# Altium schematic sheet BAT.SchDoc
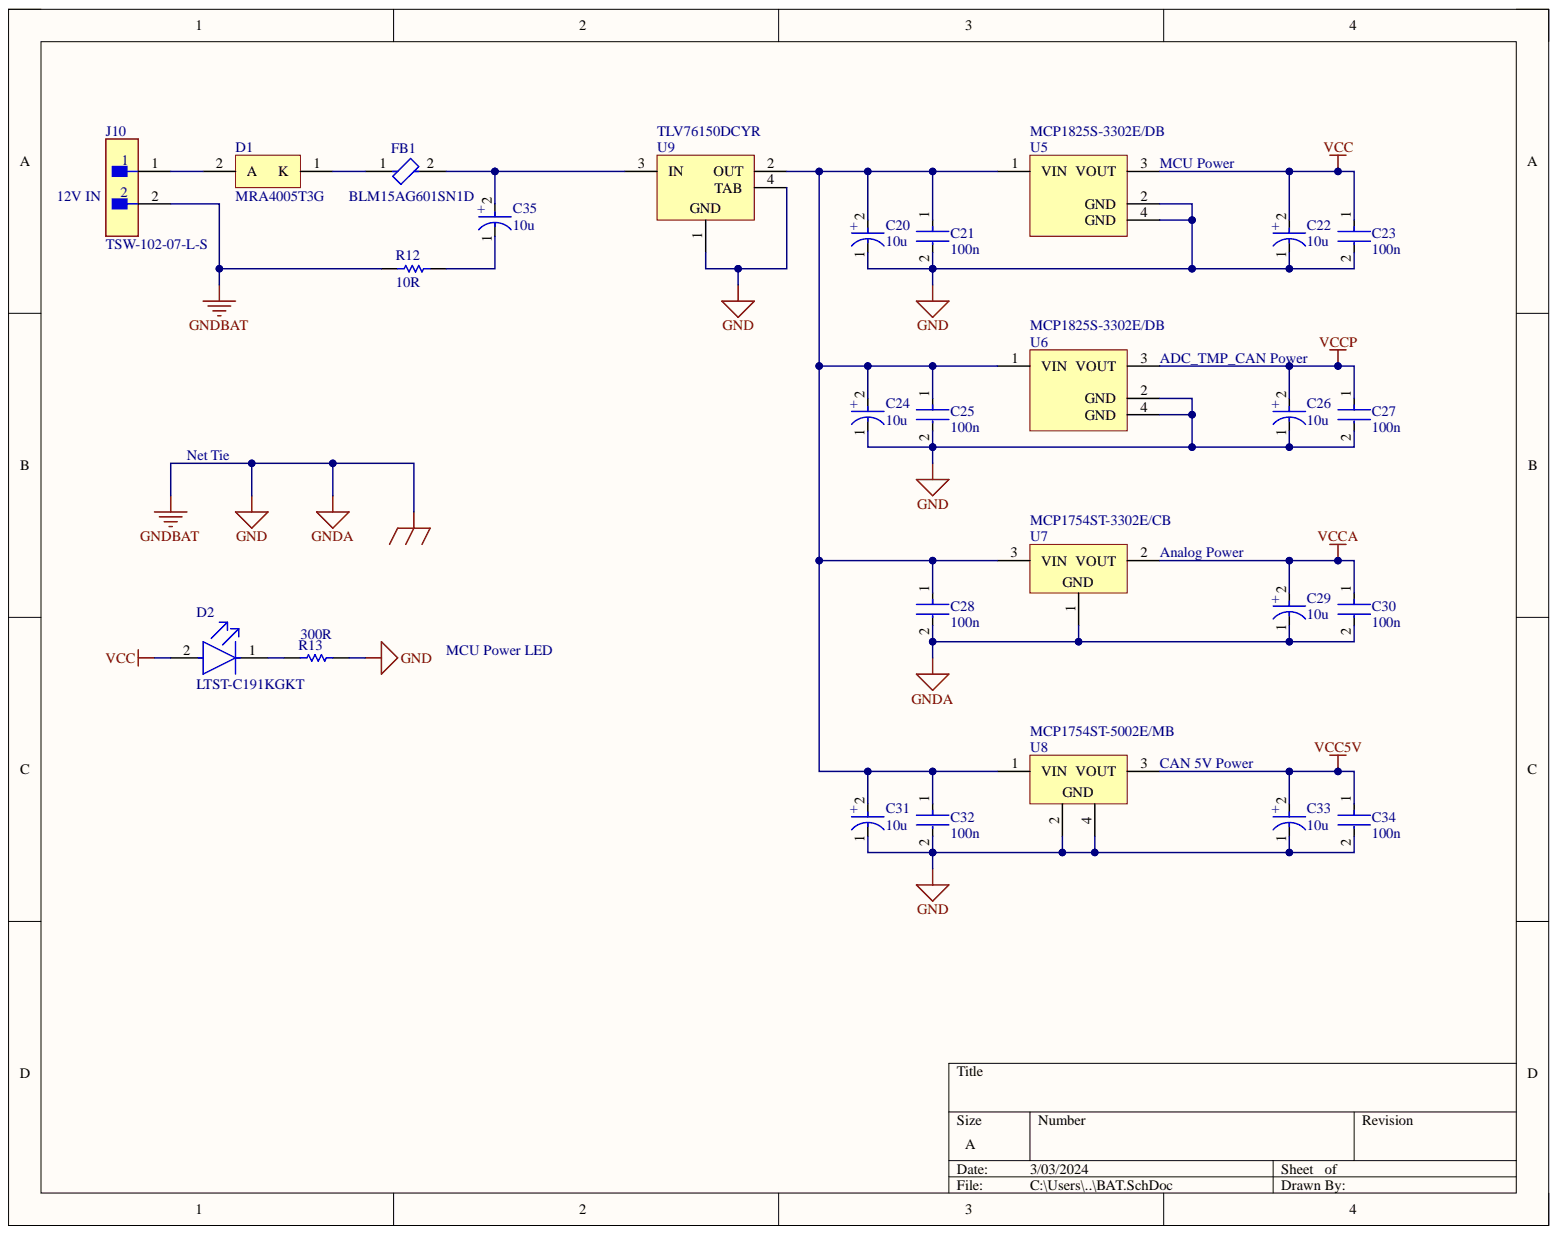
[diagram p0: BAT.SchDoc]

## Parts
C20  10u  [FP-TAJA-MFG]
C21  100n  [FP-GRM033-0_03-MFG]
C22  10u  [FP-TAJA-MFG]
C23  100n  [FP-GRM033-0_03-MFG]
C24  10u  [FP-TAJA-MFG]
C25  100n  [FP-GRM033-0_03-MFG]
C26  10u  [FP-TAJA-MFG]
C27  100n  [FP-GRM033-0_03-MFG]
C28  100n  [FP-GRM033-0_03-MFG]
C29  10u  [FP-TAJA-MFG]
C30  100n  [FP-GRM033-0_03-MFG]
C31  10u  [FP-TAJA-MFG]
C32  100n  [FP-GRM033-0_03-MFG]
C33  10u  [FP-TAJA-MFG]
C34  100n  [FP-GRM033-0_03-MFG]
C35  10u  [FP-TAJA-MFG]
D1  MRA4005T3G  [ONSC-SMA-2-403D-02_V]
D2  LTST-C191KGKT  [FP-LTST-C191KSKT-MFG]
FB1  BLM15AG601SN1D  [FP-BLM15-0_05-MFG]
J10  TSW-102-07-L-S  [FP-TSW-102-07-L-S-MFG]
R12  10R  [RESC2013X60X35ML10T20]
R13  300R  [RESC1608X55X30LL20T20]
U5  MCP1825S-3302E/DB  [SOT-223-DB3_N]
U6  MCP1825S-3302E/DB  [SOT-223-DB3_N]
U7  MCP1754ST-3302E/CB  [MCHP-SOT-23A-CB3_N]
U8  MCP1754ST-5002E/MB  [MCHP-SOT-89-MB3_L]
U9  TLV76150DCYR  [DCY0004A_L]

## Nets
GND: C20.1 C21.2 C22.1 C23.2 C24.1 C25.2 C26.1 C27.2 C28.2 C29.1 C30.2 C31.1 C32.2 C33.1 C34.2 J10.2 R12.1 R13.2 U5.2(GND) U5.4(GND) U6.2(GND) U6.4(GND) U7.1 U8.2 U8.4 U9.1 U9.4(TAB)
VCC: C22.2 C23.1 D2.2 U5.3(VOUT)
VCC5V: C33.2 C34.1 U8.3(VOUT)
VCCA: C29.2 C30.1 U7.2
VCCP: C26.2 C27.1 U6.3(VOUT)
NetC20_2: C20.2 C21.1 C24.2 C25.1 C28.1 C31.2 C32.1 U5.1(VIN) U6.1(VIN) U7.3 U8.1(VIN) U9.2(OUT)
NetC35_1: C35.1 R12.2
NetC35_2: C35.2 FB1.2 U9.3(IN)
NetD1_1: D1.1 FB1.1
NetD1_2: D1.2 J10.1
NetD2_1: D2.1 R13.1

## Library data of the parts
C20 C22 C24 C26 C29 C31 C33 C35 = CMP-04424-000097-1: 10uF 6.3V  ±10% 4Ω 1206  SMD Tantalum Capacitor; Dissipation Factor: 6%; ESR (Equivalent Series Resistance): 4R; Leakage Current: 600nA; Polarity: Polar; Q Factor: 6; Tolerance: 20%; Capacitance: 10uF; Case Code (Imperial): 1206; Case Code (Metric): 3216; Case/Package: 1206; Component Kind: Standard; Construction: Flat; Depth: 1.6mm; Dielectric Material: Tantalum; Features: General Purpose; Height: 1.6mm; Height - Seated (Max): 0.071inch; Lead Free: Lead Free; Length: 3.2mm; Library Name: Altium Content Vault; Max Operating Temperature: 125°C; Min Operating Temperature: -55°C; Mount: Surface Mount; Package Quantity: 1; Packaging: Tape and Reel; Radiation Hardening: No; REACH SVHC: No SVHC; RoHS Compliant: Yes; Series: TAJ; Termination: SMD/SMT; Voltage: 6.3V; Voltage Rating: 6.3V; Voltage Rating (DC): 6.3V; Width: 1.6mm
C21 C23 C25 C27 C28 C30 C32 C34 = CMP-06035-000062-2: Chip Multilayer Ceramic Capacitors for General Purpose, 0201, 0.10uF, X5R, 15%, 10%, 6.3V; Capacitance: 100nF; Case Code (Imperial): 0201; Case Code (Metric): 0603; Case/Package: 0201; Component Kind: Standard; Depth: 0.3mm; Dielectric: X5R; Height: 0.3mm; Lead Free: Lead Free; Length: 0.6mm; Library Name: Altium Content Vault; Material: Ceramic; Max Operating Temperature: 85°C; Min Operating Temperature: -55°C; Mount: Surface Mount; Package Quantity: 1; Packaging: Tape and Reel; Radiation Hardening: No; REACH SVHC: No SVHC; Termination: SMD/SMT; Thickness: 0.013inch; Tolerance: 10%; Voltage: 6.3V; Voltage Rating: 6.3V; Voltage Rating (DC): 6.3V; Weight: 6E-06oz; Width: 0.012inch
D1 = CMP-0894-00001-3: Surface Mount Standard Recovery Power Rectifier, 2-Pin SMA, Pb-Free, Tape and Reel; Manufacturer: On Semiconductor; IFSM Max (A): 30; IO(rec) Max (A): 1; VRRM Max (V): 600; Component Kind: Standard; ComponentLink1Description: Manufacturer URL; ComponentLink1URL: http://www.onsemi.com/; ComponentLink2Description: Datasheet; ComponentLink2URL: http://www.onsemi.com/pub_link/Collateral/MRA4003T3-D.PDF; ComponentLink3Description: Package Specification; ComponentLink3URL: http://www.onsemi.com/pub_link/Collateral/403D-02.PDF; DatasheetVersion: Rev. 9; Library Name: Altium Content Vault; Mounting Technology: Surface Mount; PackageDescription: 2-Pin Small Molded Package, J-Bend Lead, Body 2.605 x 4.315 mm; PackageReference: SMA-2-403D-02; PackageVersion: Rev. G, 07/2012; Packing: Tape and Reel; PartNumber: MRA4005T3G; RoHS: TRUE; Type: Standard Recovery
D2 = CMP-22017-000025-1: LED YELLOW CLEAR SMD; Dominant Wavelength: 597nm; Forward Current: 30mA; Forward Voltage: 2.4V; Lens Color: Colorless; Lens Style: Diffused; Luminous Intensity: 180mcd; Number of Elements: 1; Power Dissipation: 75mW; Reverse Voltage: 5V; Test Current: 20mA; Viewing Angle: 130°; Wavelength: 588nm; Case Code (Imperial): 0603; Case Code (Metric): 1608; Case/Package: 0603; Color: Yellow; Component Kind: Standard; Depth: 0.8mm; Height: 0.55mm; Illumination Color: Yellow; Lead Free: Lead Free; Length: 1.6mm; Lens Transparency: Clear; Library Name: Altium Content Vault; Max Operating Temperature: 85°C; Min Operating Temperature: -55°C; Mount: Surface Mount; Number of LEDs: 1; Number of Pins: 2; Package Quantity: 1; Packaging: Tape and Reel; Power Rating: 75mW; Radiation Hardening: No; Width: 0.8mm
FB1 = CMP-0686-00187-4: Chip Ferrite Bead, 0402, 600Ω @ 100MHz, 0.52Ω, 25%, 300mA; DC Resistance (DCR): 520mR; DC Resistance-Max: 600mR; Impedance: 600R; Material: Steel; Number of Circuits: 1; Shielding: Unshielded; Case Code (Imperial): 0402; Case Code (Metric): 1005; Case/Package: 0402; Component Kind: Standard; Core Material: Ferrite; Current Rating: 300mA; DC Current: 300mA; Depth: 0.55mm; Frequency: 100MHz; Height: 0.5mm; Lead Free: Lead Free; Length: 1mm; Library Name: Altium Content Vault; Max Current Rating: 300mA; Max DC Current: 300mA; Max Operating Temperature: 125°C; Military Standard: Not; Min Operating Temperature: -55°C; Number of Lines: 1; Number of Pins: 2; Package Quantity: 10000; Packaging: Tape and Reel; Radiation Hardening: No; REACH SVHC: No SVHC; RoHS Compliant: Yes; Series: BLM15AG_SN; Termination: SMD/SMT; Test Frequency: 100MHz; Tolerance: 25%; Width: 0.5mm
J10 = CMP-02766-001428-1: CONN HEADER VERT 2POS 2.54MM; Connector Type: Male Pin; Current Rating: 7.6A; Gender: Male; Max Voltage Rating (AC): 550V; Number of Contacts: 2; Color: Black; Component Kind: Standard; Contact Material: Bronze; Contact Mating Length: 0.23inch; Contact Plating: Gold; Contact Type: Male Pin; Depth: 2.54mm; Housing Color: Black; Housing Material: Polyester; Lead Free: Lead Free; Length: 5.08mm; Library Name: Altium Content Vault; Max Current Rating: 7.6A; Max Operating Temperature: 125°C; Min Operating Temperature: -55°C; Mount: Through Hole; Number of Positions: 2; Number of Rows: 1; Orientation: Straight; Package Quantity: 1; Packaging: Bulk; Pitch: 2.54mm; Radiation Hardening: No; REACH SVHC: No SVHC; RoHS Compliant: Yes; Series: TSW; Termination: Solder; Voltage Rating: 550V; Width: 2.54mm
R12 = CMP-2100-03670-1: Chip Resistor, 10 Ohm, +/- 1%, 0.125 W, -55 to 155 degC, 0805 (2012 Metric), RoHS, Tape and Reel; Manufacturer: Yageo; Max Power Dissipation: 125mW; Resistance: 10Ohm; Temperature Coefficient: 200ppm/degreesC; Voltage Rating: 150V; Case Code (Imperial): 0805; Case Code (Metric): 2012; Case/Package: 0805; Component Kind: Standard; Composition: ThickFilm; Datasheet URL: http://www.yageo.com/NewPortal/yageodocoutput?fileName=/pdf/R-Chip/PYu-RC_Group_51_RoHS_L_5.pdf; Datasheet Version: Rev. 5, 10/2016; Depth: 1.25mm; Height: 0.5mm; Lead Free: LeadFree; Length: 2mm; Library Name: Altium Content Vault; Manufacturer URL: http://www.yageo.com/; Max Operating Temperature: 155degC; Min Operating Temperature: -55degC; Number of Pins: 2; Number of Terminations: 2; Package Description: 2-Pin Surface Mounted Device, Body 2 x 1.25 mm; Package Quantity: 1; Package Reference: 0805; Packaging: TapeandReel; Power Rating: 125mW; REACH SVHC: NoSVHC; RoHSCompliant: true; Termination: SMD/SMT; Tolerance: 1%; Voltage Rating (DC): 150V; Width: 1.25mm
R13 = CMP-2000-00025-1: Manufacturer: Panasonic; Part Number: ERA3AEB301V; Component Kind: Standard; Library Name: Altium Content Vault
U5 U6 = CMP-0136-00458-1: 500 mA, Low Voltage, Low Quiescent Current LDO Regulator, 3-Pin SOT-223, Extended Temperature; Component Kind: Standard; DatasheetVersion: revB, Feb-2008; Library Name: Altium Content Vault; PackageDescription: 3-Lead Plastic Small Outline Transistor (DB) [SOT-223]; PackageReference: SOT-223-DB3; PackageVersion: revBB, Aug-2009
U7 = CMP-0136-01141-1: 150 mA, 16 V, High Performance LDO, -40 to 125 degC, 3-pin SOT-23A (CB3), Tape and Reel; Manufacturer: Microchip; Component Kind: Standard; ComponentLink1Description: Manufacturer URL; ComponentLink1URL: http://www.microchip.com; ComponentLink2Description: Datasheet; ComponentLink2URL: http://ww1.microchip.com/downloads/en/DeviceDoc/22276A.pdf; ComponentLink3Description: Package Specification; ComponentLink3URL: http://www.microchip.com/stellent/groups/techpub_sg/documents/packagingspec/en012702.pdf; ComponentLink4Description: Product URL; ComponentLink4URL: http://www.microchip.com/MCP1754S; DatasheetVersion: Rev. A; Library Name: Altium Content Vault; Mounting Technology: Surface Mount; PackageDescription: 3-Lead Plastic Small Outline Transistor, 2.9 x 1.5 mm Body, 0.95 mm Pitch; PackageReference: SOT-23A-CB3; PackageVersion: C04-130B; PartNumber: MCP1754ST-3302E/CB
U8 = CMP-0136-01167-1: 150 mA, 16 V, High Performance LDO, -40 to 125 degC, 3-pin SOT-89 (MB3), Tape and Reel; Manufacturer: Microchip; Component Kind: Standard; ComponentLink1Description: Manufacturer URL; ComponentLink1URL: http://www.microchip.com; ComponentLink2Description: Datasheet; ComponentLink2URL: http://ww1.microchip.com/downloads/en/DeviceDoc/22276A.pdf; ComponentLink3Description: Package Specification; ComponentLink3URL: http://www.microchip.com/stellent/groups/techpub_sg/documents/packagingspec/en012702.pdf; ComponentLink4Description: Product URL; ComponentLink4URL: http://www.microchip.com/MCP1754S; DatasheetVersion: Rev. A; Library Name: Altium Content Vault; Mounting Technology: Surface Mount; PackageDescription: 3-Lead Plastic Small Outline Transistor Header, 4.5 x 2.45 mm Body, 1.5 mm Pitch; PackageReference: SOT-89-MB3; PackageVersion: C04-029B; PartNumber: MCP1754ST-5002E/MB
U9 = CMP-0062-01637-4: 800mA Low-Dropout Linear Regulator, 4-pin SOT-223; Manufacturer: Texas Instruments; PartNumber: LM1117MPX-5.0; CompType: LDO IC; High-Reliability: Y; PackageTopMarking: XYTT*N06A; PowerWise: N; Code_IPC: SOT223-4; Code_JEDEC: TO-261-AA; Component Kind: Standard; ComponentLink1Description: Datasheet URL; ComponentLink1URL: http://www.ti.com/general/docs/lit/getliterature.tsp?genericPartNumber=LM1117-N&fileType=pdf; Library Name: Altium Content Vault; PackageDescription: Molded SOT-223, 6.50 x 3.56 x 1.6mm Body, 4 Lead, 2.3mm Pitch; PackageReference: DCY0004A; PinCount: 4; RoHS: N
